# Altium schematic sheet I_PRV_UWS_B2_1_0_main.SchDoc
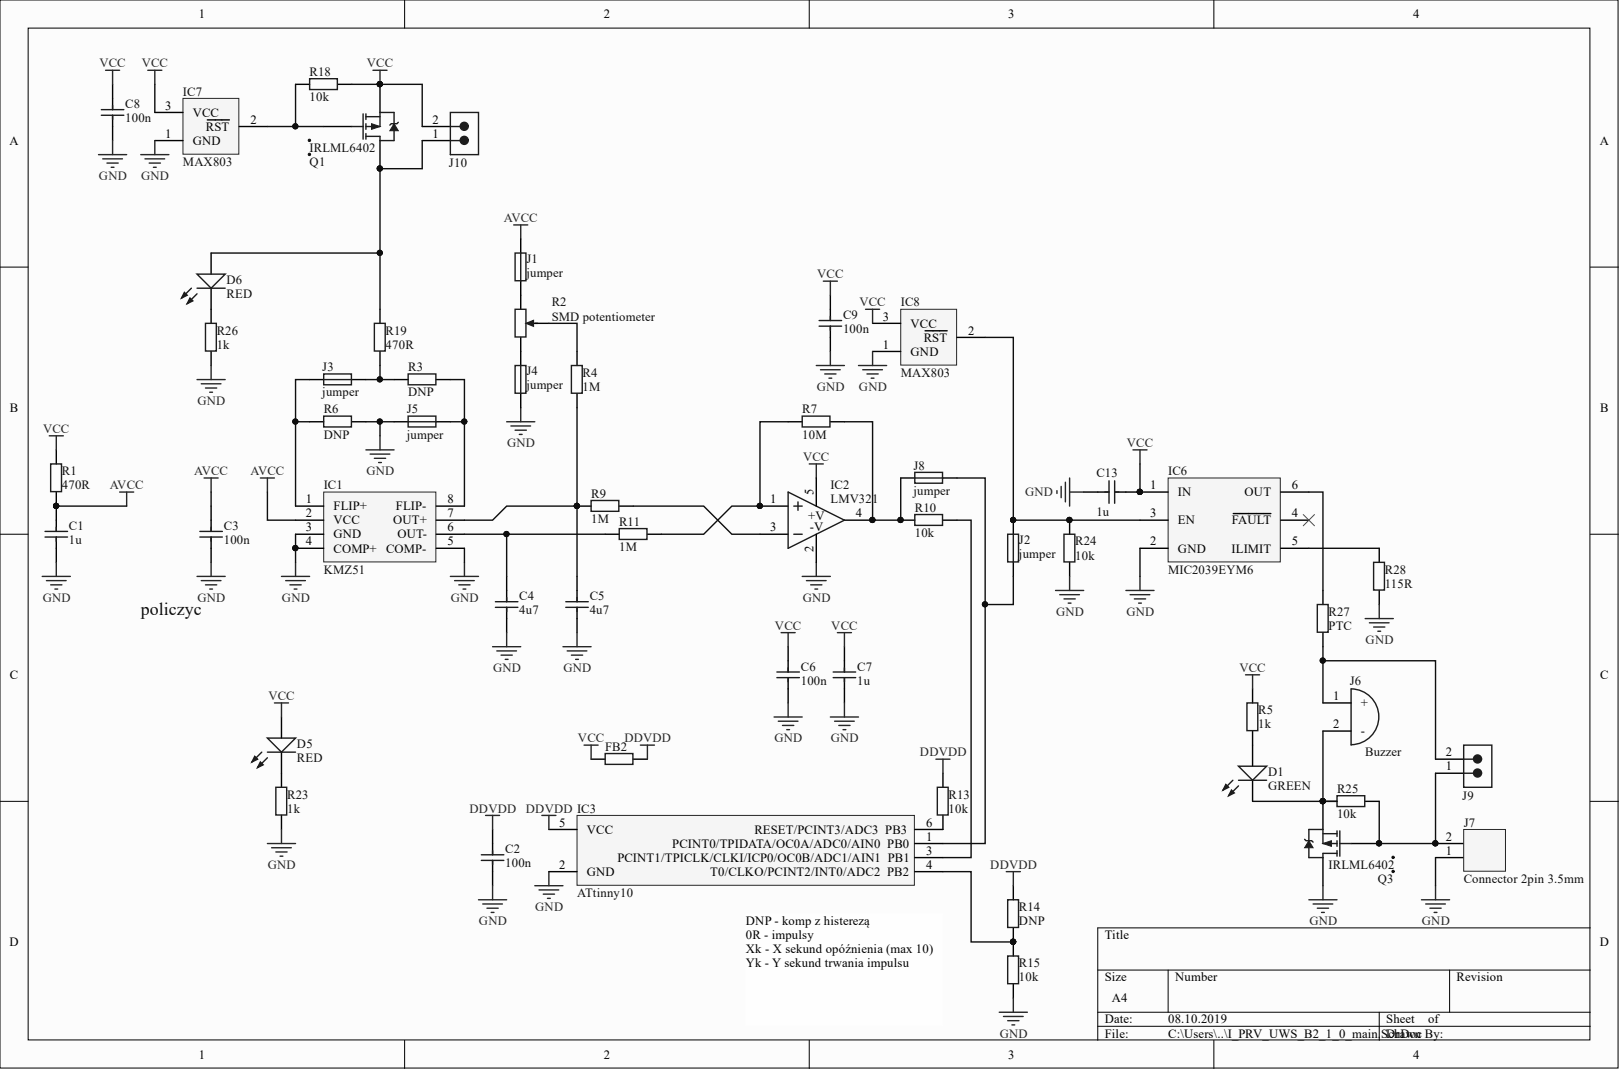
[diagram p0: I_PRV_UWS_B2_1_0_main.SchDoc]

## Parts
C1  value 1u  [SMD0402_CAP]  (hidden comment: Capacitor)
C2  value 100n  [SMD0402_CAP]  (hidden comment: Capacitor)
C3  value 100n  [SMD0402_CAP]  (hidden comment: Capacitor)
C4  value 4u7  [SMD0402_CAP]  (hidden comment: Capacitor)
C5  value 4u7  [SMD0402_CAP]  (hidden comment: Capacitor)
C6  value 100n  [SMD0402_CAP]  (hidden comment: Capacitor)
C7  value 1u  [SMD0402_CAP]  (hidden comment: Capacitor)
C8  value 100n  [SMD0402_CAP]  (hidden comment: Capacitor)
C9  value 100n  [SMD0402_CAP]  (hidden comment: Capacitor)
C13  value 1u  [SMD0603_CAP - RUAG]  (hidden comment: Capacitor)
D1  value GREEN  [SMD0603_LED]  (hidden comment: LED)
D5  value RED  [SMD0603_LED]  (hidden comment: LED)
D6  value RED  [SMD0603_LED]  (hidden comment: LED)
FB2  Resistor  [SMD0402_RES]  (value not drawn)
IC1  KMZ51  [KMZ51_SO8]
IC2  LMV321  [SOT23-5]
IC3  ATtinny10  [SOT23-6]
IC6  MIC2039EYM6  [SOT23 6L]
IC7  MAX803  [SC70-3 (SOT323)]
IC8  MAX803  [SC70-3 (SOT323)]
J1  jumper  [0402_jumper]
J2  jumper  [0402_jumper]
J3  jumper  [0402_jumper]
J4  jumper  [0402_jumper]
J5  jumper  [0402_jumper]
J6  Buzzer  [BUZZER 9.5x5]
J7  Connector 2pin 3.5mm  [Connector 2pin 3.5mm]
J8  jumper  [0402_jumper]
J9  Pinhead 2pin 2.54mm  [Pinhead 2pi 2.54mm]  (value not drawn)
J10  Pinhead 2pin 2.54mm  [Pinhead 2pi 2.54mm]  (value not drawn)
Q1  IRLML6402  [SOT_23]
Q3  IRLML6402  [SOT_23]
R1  value 470R  [SMD0402_RES]  (hidden comment: Resistor)
R2  SMD potentiometer  [Pot 1804]
R3  value DNP  [SMD0402_RES]  (hidden comment: Resistor)
R4  value 1M  [SMD0402_RES]  (hidden comment: Resistor)
R5  value 1k  [SMD0402_RES]  (hidden comment: Resistor)
R6  value DNP  [SMD0402_RES]  (hidden comment: Resistor)
R7  value 10M  [SMD0402_RES]  (hidden comment: Resistor)
R9  value 1M  [SMD0402_RES]  (hidden comment: Resistor)
R10  value 10k  [SMD0402_RES]  (hidden comment: Resistor)
R11  value 1M  [SMD0402_RES]  (hidden comment: Resistor)
R13  value 10k  [SMD0402_RES]  (hidden comment: Resistor)
R14  value DNP  [SMD0402_RES]  (hidden comment: Resistor)
R15  value 10k  [SMD0402_RES]  (hidden comment: Resistor)
R18  value 10k  [SMD0402_RES]  (hidden comment: Resistor)
R19  value 470R  [SMD0402_RES]  (hidden comment: Resistor)
R23  value 1k  [SMD0402_RES]  (hidden comment: Resistor)
R24  value 10k  [SMD0402_RES]  (hidden comment: Resistor)
R25  value 10k  [SMD0402_RES]  (hidden comment: Resistor)
R26  value 1k  [SMD0402_RES]  (hidden comment: Resistor)
R27  value PTC  [SMD1206_RES]  (hidden comment: Resistor)
R28  value 115R  [SMD0402_RES]  (hidden comment: Resistor)

## Nets
AVCC: C1.2 C3.2 IC1.2(VCC) J1.2 R1.2
DDVDD: C2.2 FB2.1 IC3.5(VCC) R13.2 R14.2
GND: C1.1 C2.1 C3.1 C4.1 C5.1 C6.1 C7.1 C8.1 C9.1 C13.2 IC1.3(GND) IC1.4(COMP+) IC1.5(COMP-) IC2.2(-V) IC3.2(GND) IC6.2(GND) IC7.1 IC8.1 J4.1 J5.2 J7.1 Q3.3 R6.2 R15.2 R23.1 R24.1 R26.1 R28.1
VCC: C6.2 C7.2 C8.2 C9.2 C13.1 D5.2 FB2.2 IC2.5(+V) IC6.1(IN) IC7.3 IC8.3 J10.2 Q1.2 R1.1 R5.2 R18.2
NetC4_2: C4.2 IC1.6(OUT-) R11.1
NetC5_2: C5.2 IC1.7(OUT+) R4.2 R9.1
NetD1_1: D1.1 J6.2 Q3.2 R25.2
NetD1_2: D1.2 R5.1
NetD5_1: D5.1 R23.2
NetD6_1: D6.1 R26.2
NetD6_2: D6.2 J10.1 Q1.3 R19.2
NetIC1_1: IC1.1(FLIP+) J3.2 R6.1
NetIC1_8: IC1.8(FLIP-) J5.1 R3.2
NetIC2_1: IC2.1 R7.1 R11.2
NetIC2_3: IC2.3 R9.2
NetIC2_4: IC2.4 J8.1 R7.2 R10.1
NetIC3_1: IC3.1(PB0) J2.1 J8.2
NetIC3_3: IC3.3(PB1) R10.2
NetIC3_4: IC3.4(PB2) R14.1 R15.1
NetIC3_6: IC3.6(PB3) R13.1
NetIC6_3: IC6.3(EN) IC8.2 J2.2 R24.2
NetIC6_5: IC6.5(ILIMIT) R28.2
NetIC6_6: IC6.6(OUT) R27.2
NetIC7_2: IC7.2 Q1.1 R18.1
NetJ1_1: J1.1 R2.1
NetJ3_1: J3.1 R3.1 R19.1
NetJ4_2: J4.2 R2.2
NetJ6_1: J6.1 J9.2 R27.1
NetJ7_2: J7.2 J9.1 Q3.1 R25.1
NetR2_3: R2.3 R4.1

Not connected: IC6 4(FAULT)

## Library data of the parts
IC2 = LMV321: LMV321 Opamp; Component Kind: Standard; LCSC Part #: C7972; Library Name: BM_UWS.SchLib
IC3 = ATtiny10: Component Kind: Standard; Library Name: BM_UWS.SchLib
J1-J5 J8 = 0402 jumper: Component Kind: Standard; Library Name: BM_UWS.SchLib
Q1 Q3 = IRLML6402: HEXFET Power P-Channel MOSFET; Component Kind: Standard; LCSC Part #: C15127; Library Name: TCH_SCH_LIB.SchLib
R2 = Potentiometer 1804 (3314): Component Kind: Standard; Library Name: BM_UWS.SchLib
C1-C9 C13 = Capacitor: Component Kind: Standard; Description: Capacitor; Library Name: KD_SCH_LIB.SchLib
  C1 C7 C13: LCSC Part #: C52923
  C2 C3 C6 C8 C9: LCSC Part #: C1525
  C4 C5: LCSC Part #: C23733
D1 D5 D6 = LED: Component Kind: Standard; Description: LED; Library Name: KD_SCH_LIB.SchLib
  D1: LCSC Part #: C72043
  D5 D6: LCSC Part #: C2286
FB2 R1 R3-R7 R9-R11 R13-R15 R18 R19 R23-R28 = Resistor: Component Kind: Standard; Description: Resistor; Library Name: KD_SCH_LIB.SchLib
  FB2: LCSC Part #: C25086
  R1 R19: LCSC Part #: C25117
  R4 R9 R11: LCSC Part #: C26083
  R5 R23 R26: LCSC Part #: C11702
  R7: LCSC Part #: C26082
  R10 R13 R15 R18 R24 R25 R28: LCSC Part #: C25744
IC1 = KMZ51: Component Kind: Standard; Library Name: BM_UWS.SchLib
IC6 = MIC2039EYM6: Component Kind: Standard; Description: MIC2039EYM6; Library Name: BM_SCH_LIB.SchLib
IC7 IC8 = MAX803: Component Kind: Standard; Library Name: BM_SCH_LIB.SchLib
J6 = Buzzer: Component Kind: Standard; Library Name: BM_UWS.SchLib
J7 = Connector 2pin 3.5mm: Component Kind: Standard; Library Name: BM_UWS.SchLib
J9 J10 = Pinhead 2pin 2.54mm: Component Kind: Standard; Library Name: BM_UWS.SchLib
